# Altium schematic sheet serial.SchDoc
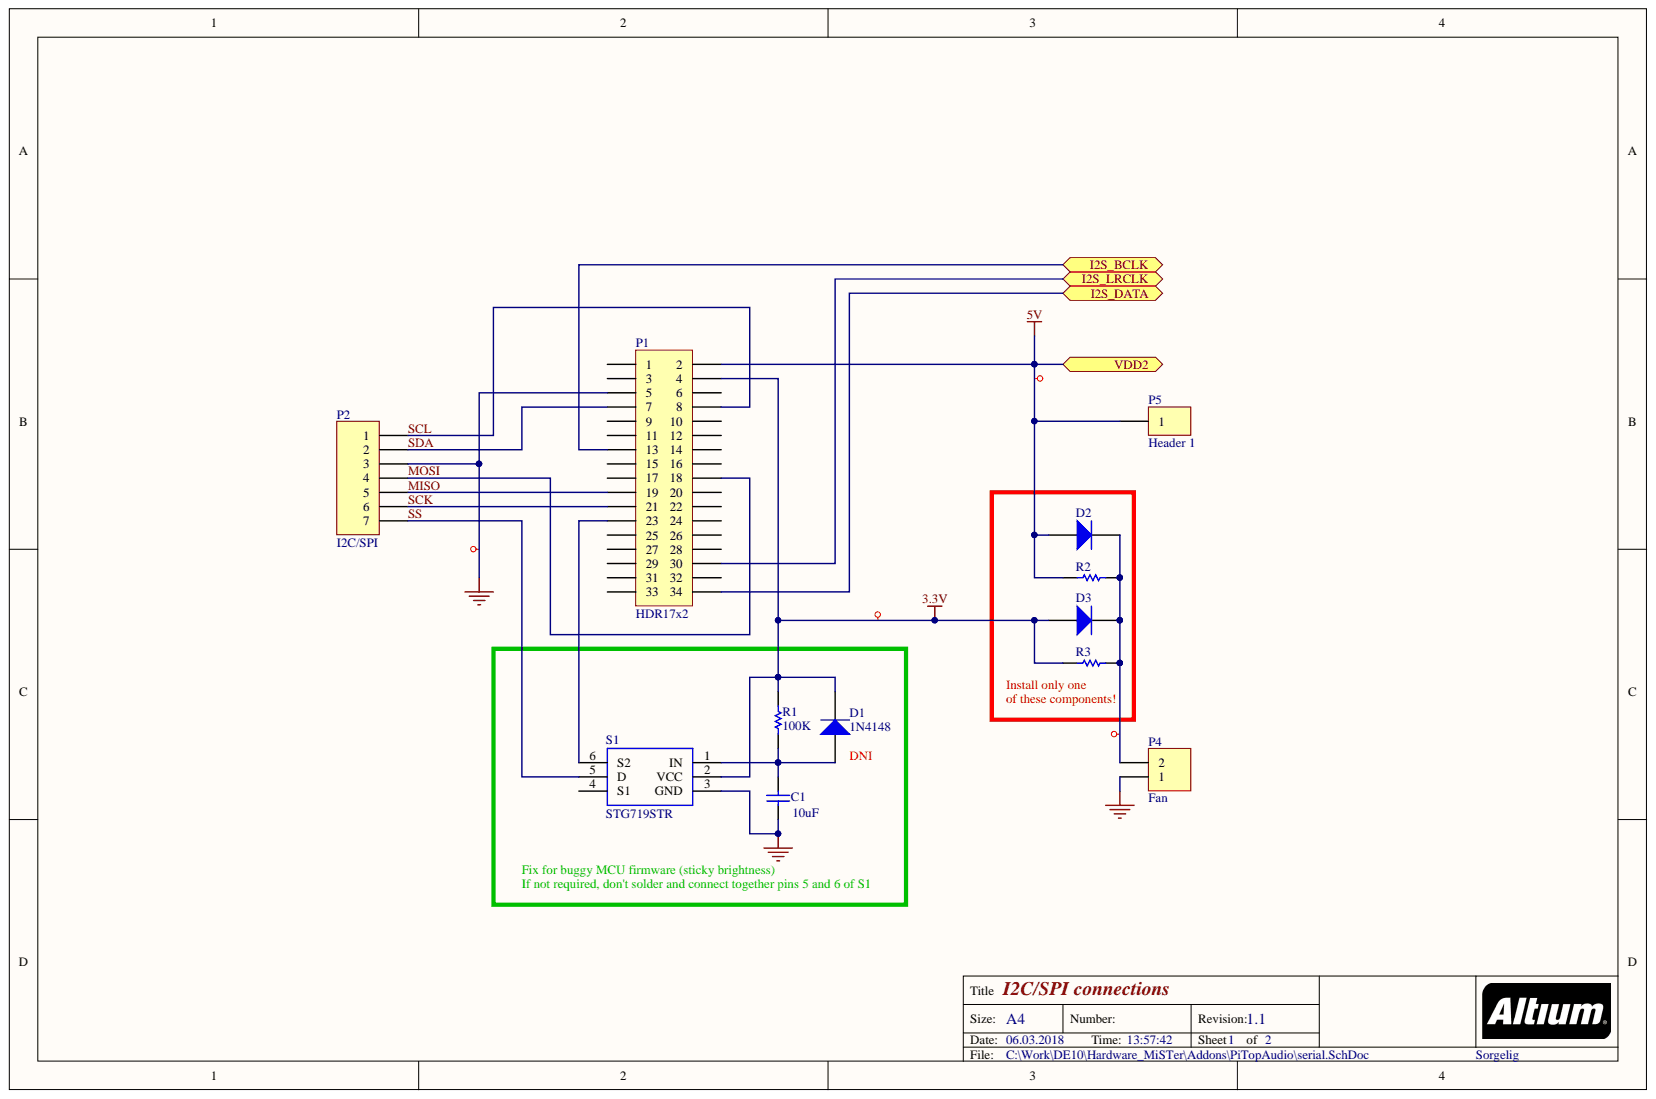
[diagram p0: serial.SchDoc]

## Parts
C1  value 10uF  [C0805]  (hidden comment: C0805)
D1  1N4148  [DIODE]
D2  Diode  [DIODE]  (value not drawn)
D3  Diode  [DIODE]  (value not drawn)
P1  HDR17x2  [HDR17X2_90]
P2  I2C/SPI  [HDR1X7]
P4  Fan  [HDR1X2]
P5  Header 1  [Header 1]
R1  100K  [R0805]
R2  10  [6-0805]  (value not drawn)
R3  10  [6-0805]  (value not drawn)
S1  STG719STR  [SOT23-6_L]

## Ports
I2S_BCLK, I2S_DATA, I2S_LRCLK, VDD2

## Nets
3.3V: D1.2 D3.1 P1.4 R1.2 R3.1 S1.2(VCC)
GND: C1.1 P1.5 P2.3 P4.1 S1.3(GND)
5V: D2.1 P1.2 P5.1 R2.1 ; port VDD2
I2S_BCLK: P1.13 ; port I2S_BCLK
I2S_DATA: P1.34 ; port I2S_DATA
I2S_LRCLK: P1.30 ; port I2S_LRCLK
MISO: P1.19 P2.5
MOSI: P1.18 P2.4
SCK: P1.21 P2.6
SCL: P1.8 P2.1
SDA: P1.7 P2.2
SS: P2.7 S1.5(D)
NetC1_2: C1.2 D1.1 R1.1 S1.1(IN)
NetD2_2: D2.2 D3.2 P4.2 R2.2 R3.2
NetP1_23: P1.23 S1.6(S2)

Not connected: P1 1 3 6 9 10 11 12 14 15 16 17 20 22 24 25 26 27 28 29 31 32 33; S1 4

## Library data of the parts
C1 = C0805: Ceramic Chip Capacitor - Standard; Note: Footprint corresponds to dimensions for bulk cassette packaging. This case size has tightened tolerances.; Code_IPC: 2012[0805]; Code_JEITA: 0805; Component Kind: Standard; ComponentLink1Description: Manufacturer Link; ComponentLink1URL: http://www.kemet.com/; ComponentLink2Description: Datasheet; ComponentLink2URL: http://www.kemet.com/kemet/web/homepage/kechome.nsf/vapubfilesname/F3102TA.pdf/$file/F3102TA.pdf; DatasheetDocument: Mar-2001; LatestRevisionDate: 19-Mar-2004; LatestRevisionNote: Silkscreen size reduced.; Library Name: KEMET Chip Capacitor.IntLib; PackageDocument: Mar-2001; PackageReference: 0805; Published: 17-Jul-2002; Signal Integrity: C0805; Simulation: CAP; Publisher: Altium Limited
D1-D3 = Diode: Default Diode; Code_JEDEC: DO-214-AB; Component Kind: Standard; LatestRevisionDate: 17-Jul-2002; LatestRevisionNote: Re-released for DXP Platform.; Library Name: Miscellaneous Devices.IntLib; PackageDescription: DO-214-AB/SMC; 2 C-Bend Leads; Body 7.9 x 5.9 mm, inc. leads (LxW); PackageReference: SMC; PackageVersion: Sep-1996; Published: 8-Jun-2000; Signal Integrity: Diode; Simulation: DIODE; Publisher: Altium Limited
P2 = Header 7: Header, 7-Pin; Component Kind: Standard; LatestRevisionDate: 17-Jul-2002; LatestRevisionNote: Re-released for DXP Platform.; Library Name: Miscellaneous Connectors.IntLib; Signal Integrity: Connector; Publisher: Altium Limited
P4 = Header 2: Header, 2-Pin; Component Kind: Standard; LatestRevisionDate: 17-Jul-2002; LatestRevisionNote: Re-released for DXP Platform.; Library Name: Miscellaneous Connectors.IntLib; Signal Integrity: Connector; Publisher: Altium Limited
R1-R3 = ERJ6RS: Low Ohmic Value Thick Film Chip Resistor, 0.1 Ohm to 0.2 Ohm Range, 1%, 2% and 5% Tolerance, 0805 Size, 0.125 W; Code_IPC: 2012 [0805]; Component Kind: Standard; ComponentLink1Description: Manufacturer Link; ComponentLink1URL: http://www.panasonic.com/industrial/components/resistive_products.htm; ComponentLink2Description: Datasheet; ComponentLink2URL: http://www.panasonic.com/industrial/components/pdf/AOA0000CE3.pdf; LatestRevisionDate: 19-Mar-2004; LatestRevisionNote: Silkscreen size reduced.; Library Name: Panasonic Resistor.IntLib; PackageDocument: Mar-2003; PackageReference: 6-0805; Published: 17-Dec-2003; Signal Integrity: Res; Simulation: RESISTOR; Publisher: Altium Limited
S1 = STG719STR: STMICROELECTRONICS - STG719STR - IC, SWITCH, SPDT, SMD; Geometry.Height: 1.45mm; Component Kind: Standard; Datasheet Link: http://www.st.com/content/ccc/resource/technical/document/datasheet/10/33/73/59/23/59/49/0b/CD00002039.pdf/files/CD00002039.pdf/jcr:content/translations/en.CD00002039.pdf; Library Name: lib.SchLib; Manufacturer_Name: STMicroelectronics; Manufacturer_Part_Number: STG719STR; Supplier_Name: RS
P1 = HDR17x2: Component Kind: Standard; Library Name: lib.SchLib
P5 = Header 1: Component Kind: Standard; Library Name: lib.SchLib
